# Altium schematic sheet 02.IP101GRI.SchDoc
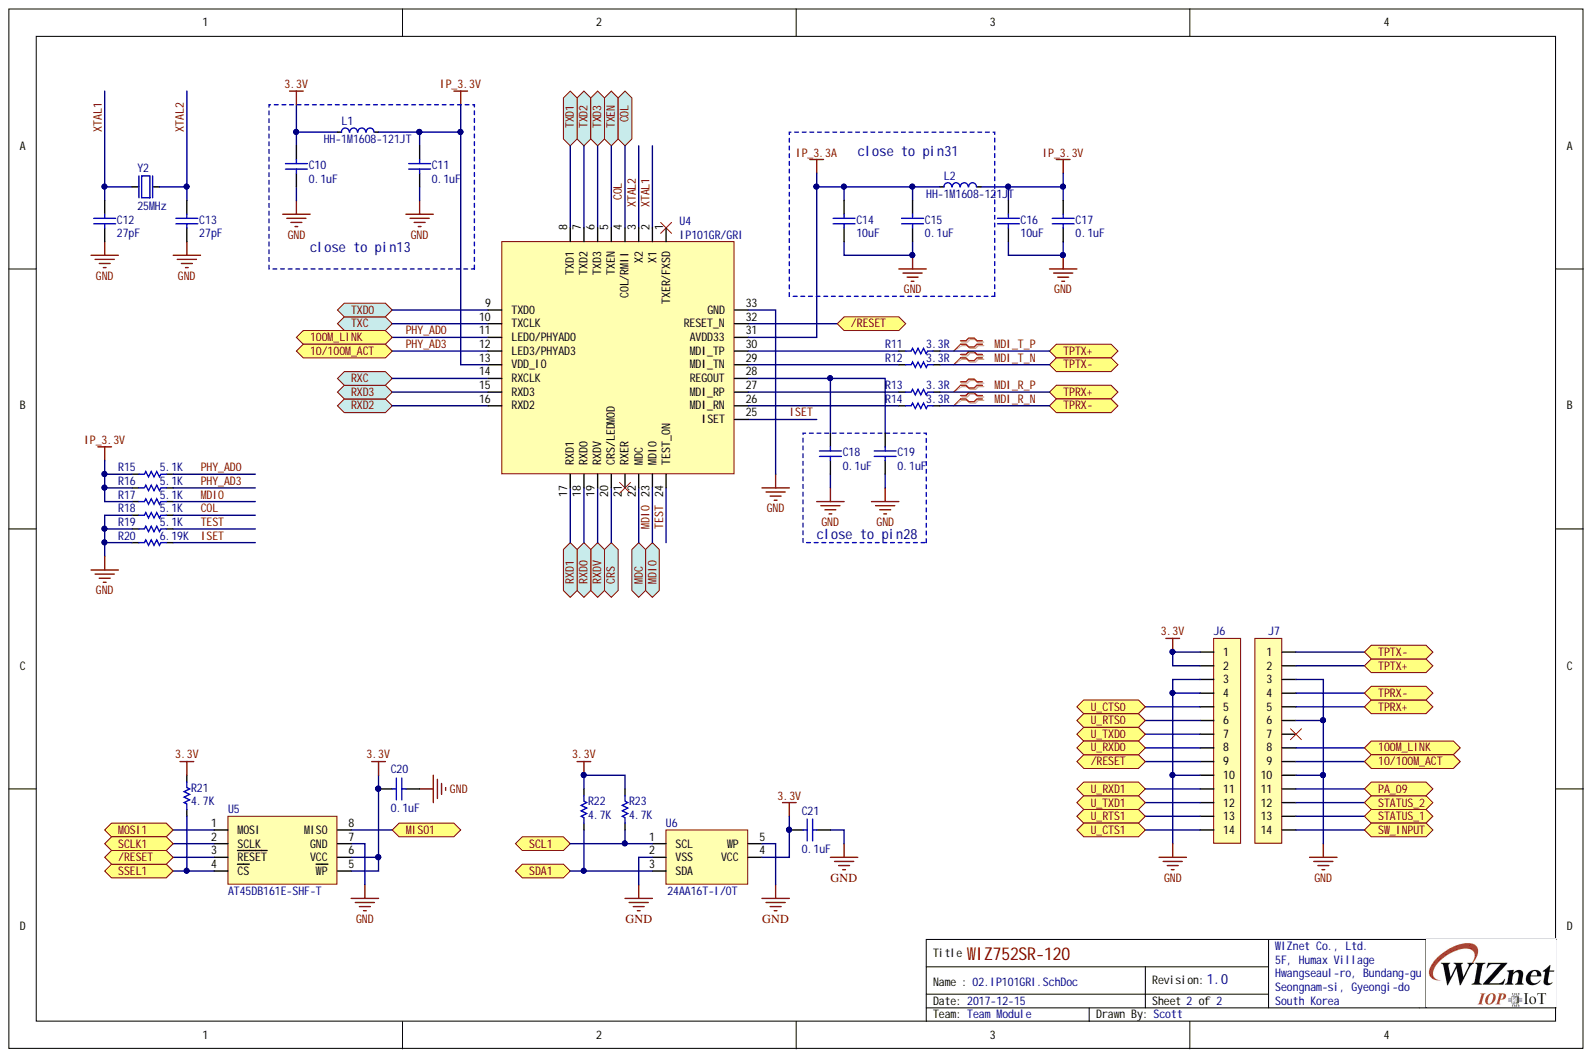
[diagram p0: 02.IP101GRI.SchDoc]

## Parts
C10  0.1uF  [CAP-0603]
C11  0.1uF  [CAP-0603]
C12  27pF  [CAP-0603]
C13  27pF  [CAP-0603]
C14  10uF  [CAP-1206]
C15  0.1uF  [CAP-0603]
C16  10uF  [CAP-1206]
C17  0.1uF  [CAP-0603]
C18  0.1uF  [CAP-0603]
C19  0.1uF  [CAP-0603]
C20  0.1uF  [CAP-0603]
C21  0.1uF  [CAP-0603]
J6  2.00mm 1x14 Pin Header DIP  [IDC-1X14(2MM)]  (value not drawn)
J7  2.00mm 1x14 Pin Header DIP  [IDC-1X14(2MM)]  (value not drawn)
L1  HH-1M1608-121JT  [IND-0603]
L2  HH-1M1608-121JT  [IND-0603]
R11  3.3R  [RES-0603]
R12  3.3R  [RES-0603]
R13  3.3R  [RES-0603]
R14  3.3R  [RES-0603]
R15  5.1K  [RES-0603]
R16  5.1K  [RES-0603]
R17  5.1K  [RES-0603]
R18  5.1K  [RES-0603]
R19  5.1K  [RES-0603]
R20  6.19K  [RES-0603]
R21  4.7K  [RES-0603]
R22  4.7K  [RES-0603]
R23  4.7K  [RES-0603]
U4  IP101GR/GRI  [QFN32(0.4/4X4)]
U5  AT45DB161E-SHF-T  [SO-08-EIAJ]
U6  24AA16T-I/OT  [SOT-23-5L]
Y2  25MHz  [OSC-82J0626]

## Ports
10/100M_ACT, 100M_LINK, /RESET, COL, CRS, MDC, MDIO, MISO1, MOSI1, PA_09, RXC, RXD0, RXD1, RXD2, RXD3, RXDV, SCL1, SCLK1, SDA1, SSEL1, STATUS_1, STATUS_2, SW_INPUT, TPRX+, TPRX-, TPTX+, TPTX-, TXC, TXD0, TXD1, TXD2, TXD3, TXEN, U_CTS0, U_CTS1, U_RTS0, U_RTS1, U_RXD0, U_RXD1, U_TXD0, U_TXD1

## Nets
3.3V: C10.2 C20.2 C21.2 J6.1 J6.2 L1.1 R21.2 R22.2 R23.2 U5.5(WP) U5.6(VCC) U6.4(VCC)
GND: C10.1 C11.1 C12.1 C13.1 C14.1 C15.1 C16.1 C17.1 C18.1 C19.1 C20.1 C21.1 J6.3 J6.4 J6.10 J7.3 J7.6 J7.10 R18.2 R19.2 R20.2 U4.33(GND) U5.7(GND) U6.2(VSS) U6.5(WP) Y2.2 Y2.4
IP_3.3A: C14.2 C15.2 L2.1 U4.31(AVDD33)
IP_3.3V: C11.2 C16.2 C17.2 L1.2 L2.2 R15.2 R16.2 R17.2 U4.13(VDD_IO)
/RESET: J6.9 U4.32(RESET_N) U5.3(RESET) ; port /RESET
COL: R18.1 U4.4(COL/RMII) ; port COL
CRS: U4.20(CRS/LEDMOD) ; port CRS
ISET: R20.1 U4.25(ISET)
MDC: U4.22(MDC) ; port MDC
MDI_R_N: J7.4 R14.1
MDI_R_P: J7.5 R13.1
MDI_T_N: J7.1 R12.1
MDI_T_P: J7.2 R11.1
MDIO: R17.1 U4.23(MDIO) ; port MDIO
MISO1: U5.8(MISO) ; port MISO1
MOSI1: U5.1(MOSI) ; port MOSI1
PA_09: J7.11 ; port PA_09
PHY_AD0: J7.8 R15.1 U4.11(LED0/PHYAD0) ; port 100M_LINK
PHY_AD3: J7.9 R16.1 U4.12(LED3/PHYAD3) ; port 10/100M_ACT
RXC: U4.14(RXCLK) ; port RXC
RXD0: U4.18(RXD0) ; port RXD0
RXD1: U4.17(RXD1) ; port RXD1
RXD2: U4.16(RXD2) ; port RXD2
RXD3: U4.15(RXD3) ; port RXD3
RXDV: U4.19(RXDV) ; port RXDV
SCL1: R23.1 U6.1(SCL) ; port SCL1
SCLK1: U5.2(SCLK) ; port SCLK1
SDA1: R22.1 U6.3(SDA) ; port SDA1
SSEL1: R21.1 U5.4(CS) ; port SSEL1
STATUS_1: J7.13 ; port STATUS_1
STATUS_2: J7.12 ; port STATUS_2
SW_INPUT: J7.14 ; port SW_INPUT
TEST: R19.1 U4.24(TEST_ON)
TXC: U4.10(TXCLK) ; port TXC
TXD0: U4.9(TXD0) ; port TXD0
TXD1: U4.8(TXD1) ; port TXD1
TXD2: U4.7(TXD2) ; port TXD2
TXD3: U4.6(TXD3) ; port TXD3
TXEN: U4.5(TXEN) ; port TXEN
U_CTS0: J6.5 ; port U_CTS0
U_CTS1: J6.14 ; port U_CTS1
U_RTS0: J6.6 ; port U_RTS0
U_RTS1: J6.13 ; port U_RTS1
U_RXD0: J6.8 ; port U_RXD0
U_RXD1: J6.11 ; port U_RXD1
U_TXD0: J6.7 ; port U_TXD0
U_TXD1: J6.12 ; port U_TXD1
XTAL1: C12.2 U4.2(X1) Y2.1
XTAL2: C13.2 U4.3(X2) Y2.3
NetC18_2: C18.2 C19.2 U4.28(REGOUT)
NetR11_2: R11.2 U4.30(MDI_TP)
NetR12_2: R12.2 U4.29(MDI_TN)
NetR13_2: R13.2 U4.27(MDI_RP)
NetR14_2: R14.2 U4.26(MDI_RN)

Not connected: J7 7; U4 1(TXER/FXSD) 21(RXER)

## Library data of the parts
C10-C13 C15 C17-C21 = CAP-0603: Ceramic CAP 0603(1608); Component Kind: Standard; Library Name: Scott_lib.IntLib
C14 C16 = CAP-1206: Ceramic CAP 1206(3216); Component Kind: Standard; Library Name: Scott_lib.IntLib
L1 L2 = IND-0603: Inductor 0603(1608); Component Kind: Standard; Library Name: Scott_lib.IntLib
R11-R23 = RES-0603: RES0603; Component Kind: Standard; Library Name: Scott_lib.IntLib
U4 = IP101GR/GRI: Pakage: VQFN-32; Component Kind: Standard; Part_name: IP101GR/GRI; Library Name: Edward.IntLib
U5 = AT45DB161E-SHF-T: 16-Mbit DataFlash, 2.3V or 2.5V Minimum SPI Serial Flash Memory; Component Kind: Standard; Library Name: Edward.IntLib
U6 = 24AAxxT-i-OT: SOT-23; Component Kind: Standard; Library Name: 24AAxxT-OT.SchLib
Y2 = OSC-82J0626-25MHz: X-tal 25MHz,3.2x2.5 SMD, CL=18pF; Component Kind: Standard; Library Name: Scott_lib.IntLib
J6 J7 = 2.00mm 1x14 Pin Header DIP: Component Kind: Standard; Description: 2.00mm 1x14 Pin Header DIP; Library Name: Scott_lib.IntLib
